# Altium schematic sheet Battery Charger.SchDoc(U_BATTERY_CHARGER)
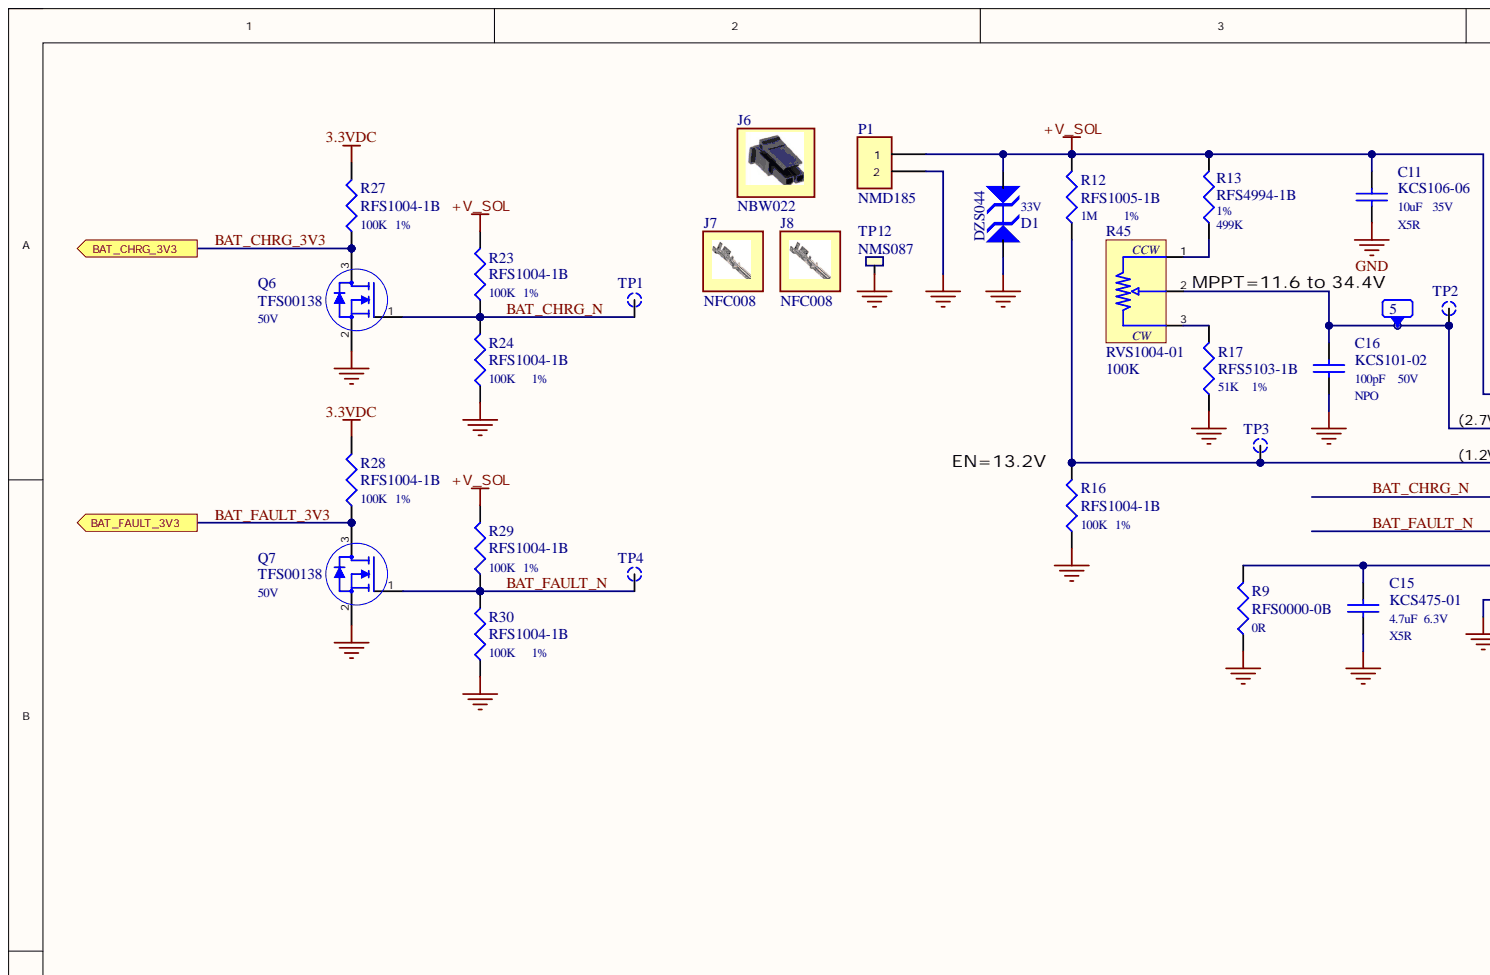
[diagram p0: Battery Charger.SchDoc, top left]
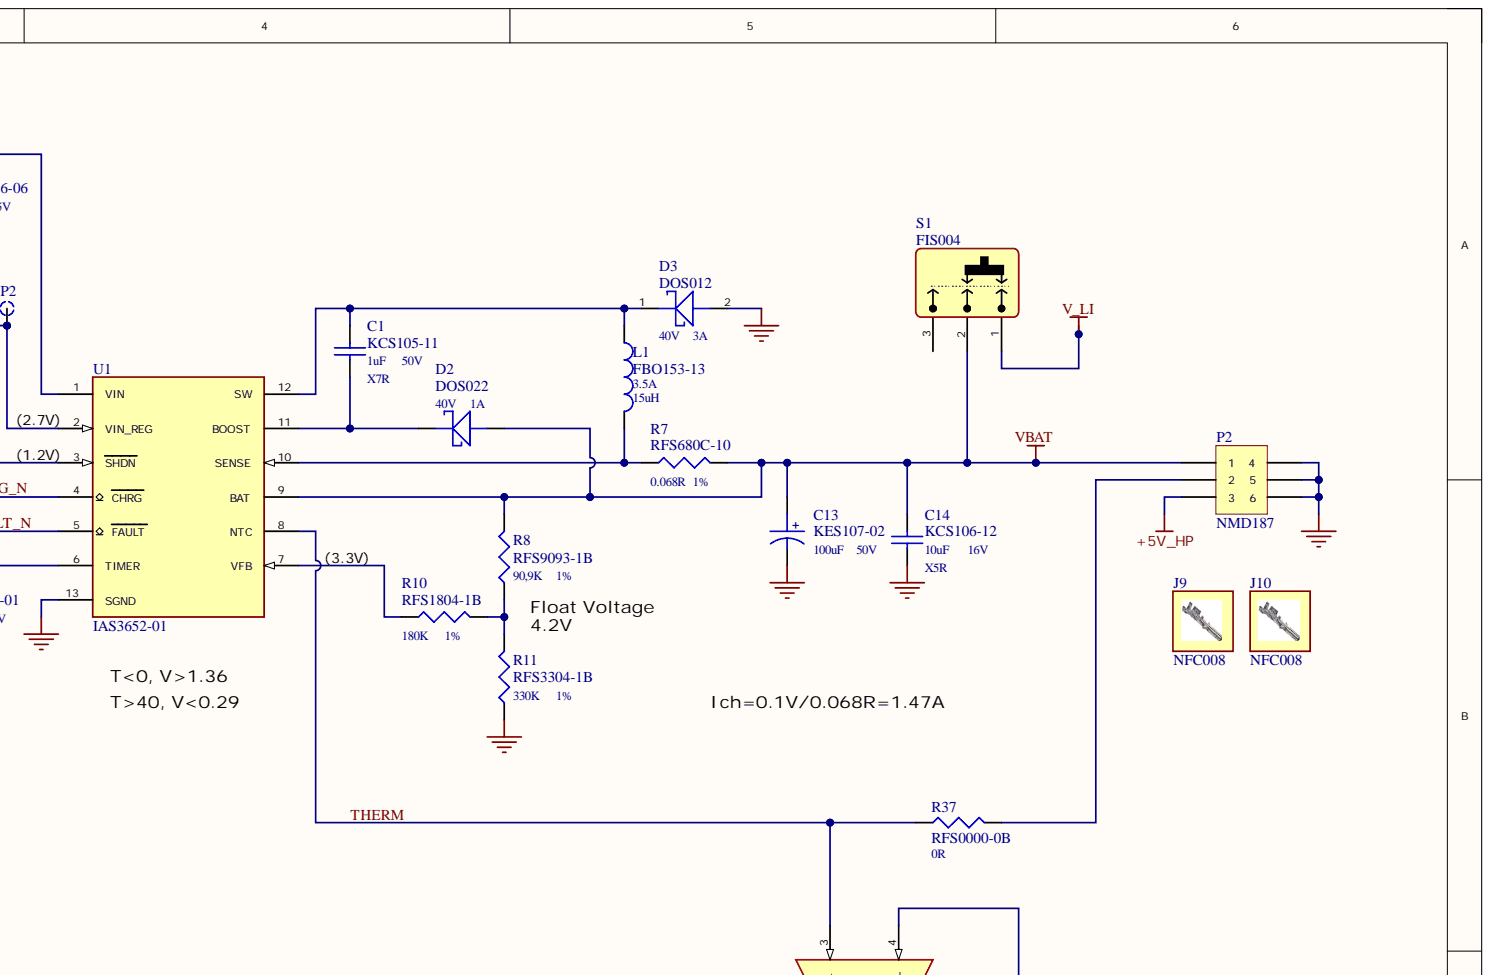
[diagram p1: Battery Charger.SchDoc, top right]
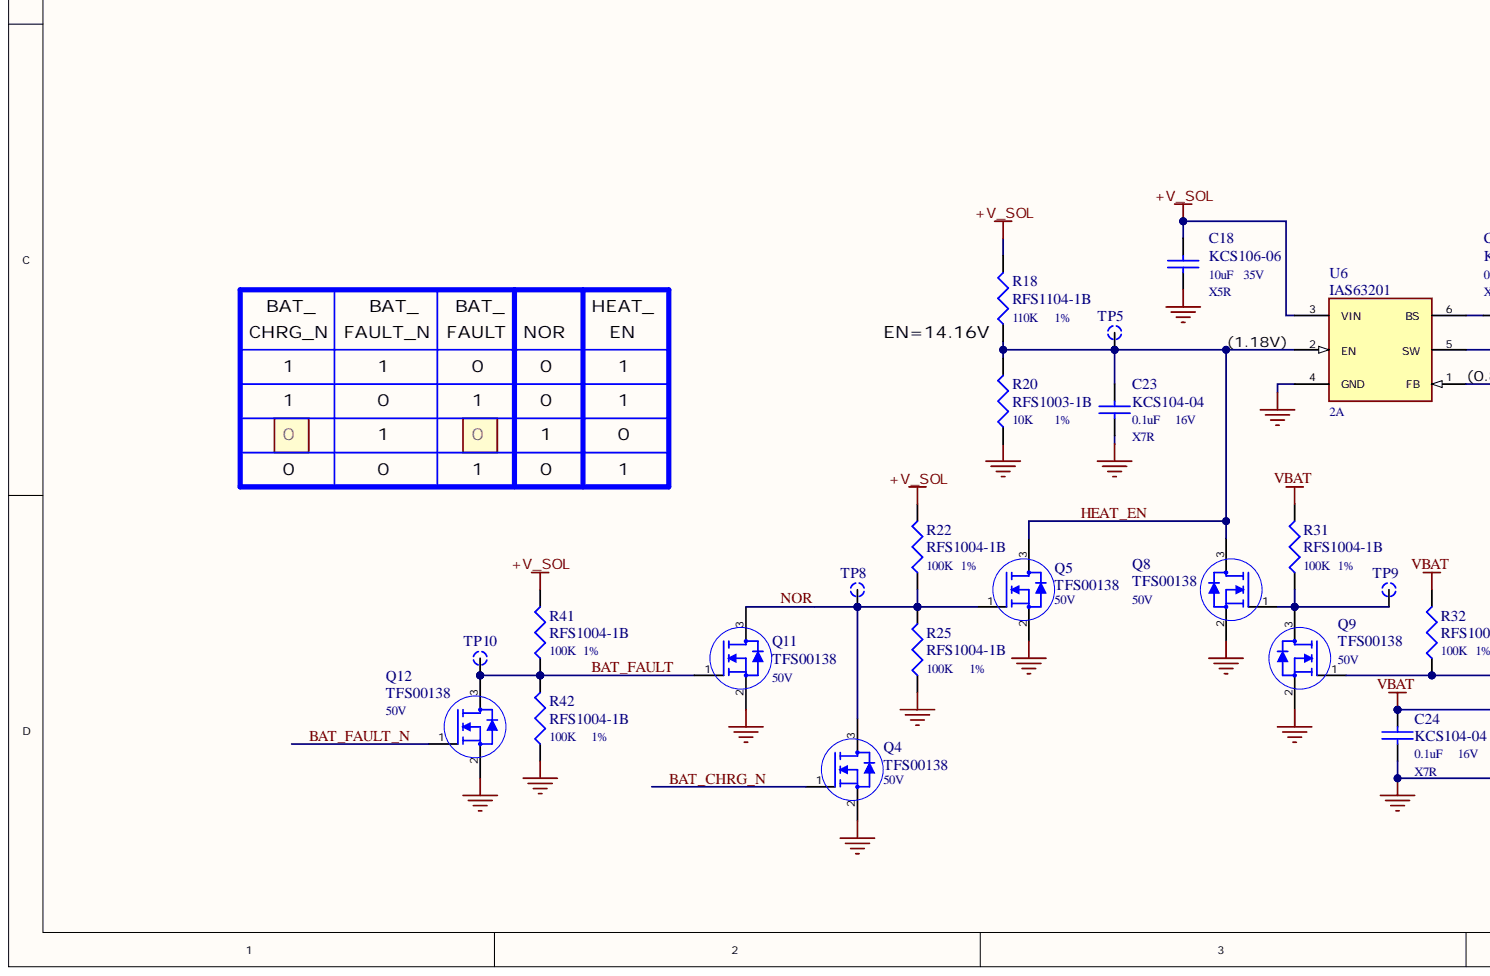
[diagram p2: Battery Charger.SchDoc, bottom left]
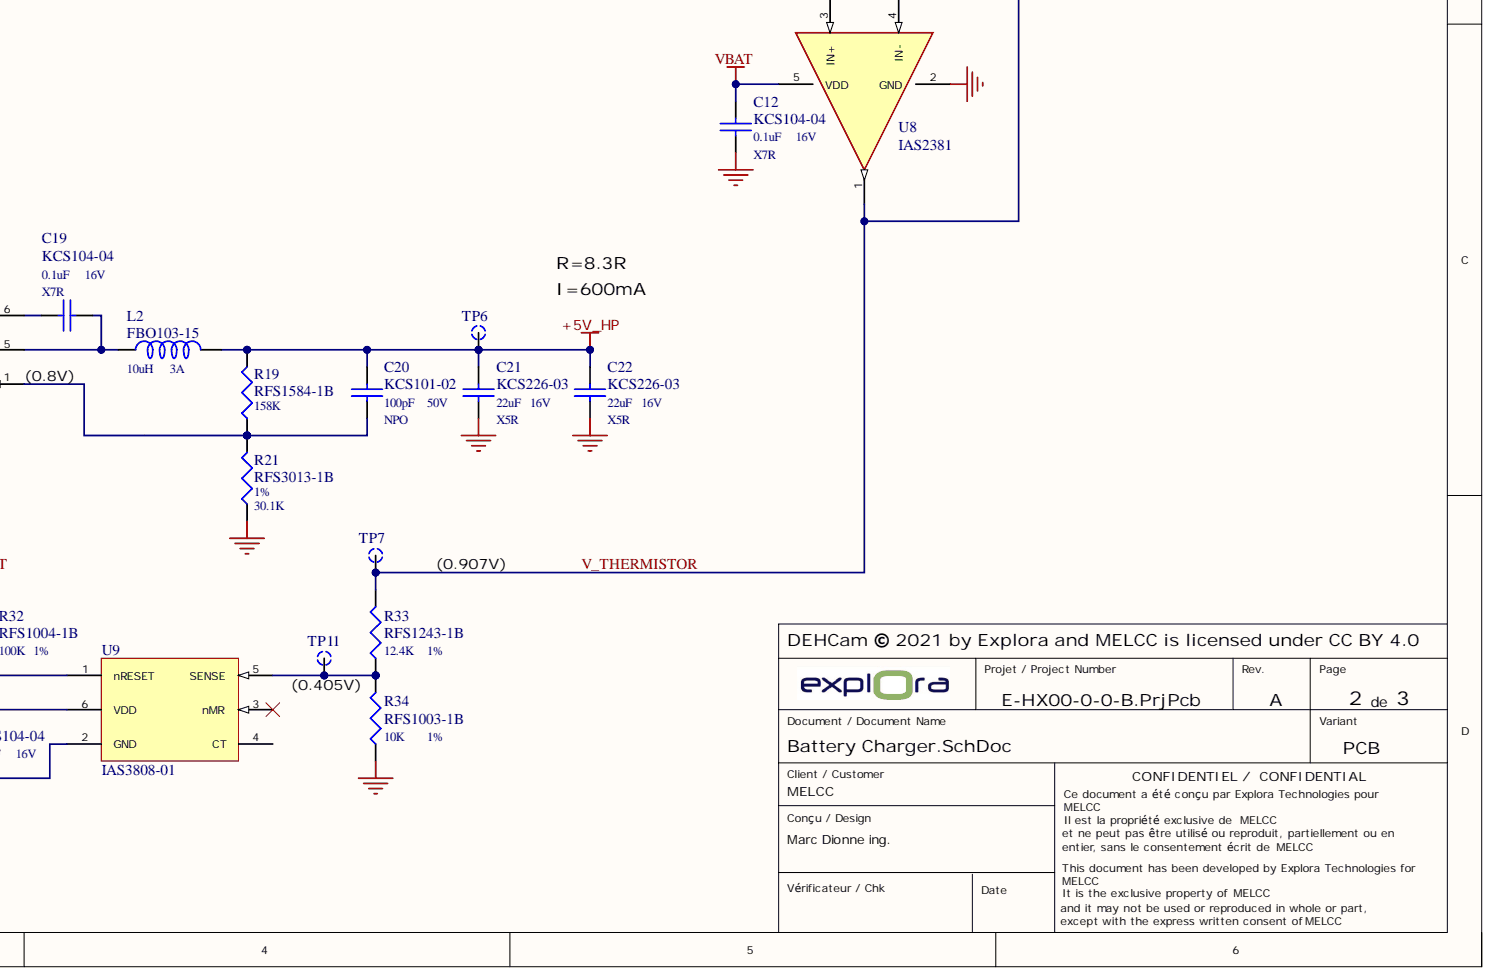
[diagram p3: Battery Charger.SchDoc, bottom right]

## Parts
5  TESTPOINT_SMALL  [TESTP_THRU - SMALL]  (value not drawn)  (no BOM)
C1  KCS105-11  1_VAL: 1uF  7_TYPE: X7R  [0603]
C11  KCS106-06  1_VAL: 10uF  7_TYPE: X5R  [1206]
C12  KCS104-04  1_VAL: 0.1uF  7_TYPE: X7R  [0402]
C13  KES107-02  1_VAL: 100uF  [KES107-02]
C14  KCS106-12  1_VAL: 10uF  7_TYPE: X5R  [0805]
C15  KCS475-01  1_VAL: 4.7uF  3_V: 6.3V  7_TYPE: X5R  [0603]
C16  KCS101-02  1_VAL: 100pF  7_TYPE: NPO  [0402]
C18  KCS106-06  1_VAL: 10uF  3_V: 35V  7_TYPE: X5R  [1206]
C19  KCS104-04  1_VAL: 0.1uF  3_V: 16V  7_TYPE: X7R  [0402]
C20  KCS101-02  1_VAL: 100pF  7_TYPE: NPO  [0402]
C21  KCS226-03  1_VAL: 22uF  7_TYPE: X5R  [1206]
C22  KCS226-03  1_VAL: 22uF  3_V: 16V  7_TYPE: X5R  [1206]
C23  KCS104-04  1_VAL: 0.1uF  7_TYPE: X7R  [0402]
C24  KCS104-04  1_VAL: 0.1uF  7_TYPE: X7R  [0402]
D1  DZS044  3_V: 33V  [SMC]
D2  DOS022  3_V: 40V  4_I: 1A  [SOD-123POL]
D3  DOS012  3_V: 40V  4_I: 3A  [SMA]
J6  NBW022
J7  NFC008
J8  NFC008
J9  NFC008
J10  NFC008
L1  FBO153-13  1_VAL: 15uH  4_I: 3.5A  [FBO153-13]
L2  FBO103-15  1_VAL: 10uH  4_I: 3A  [744065XXX]
P1  NMD185  [NMD185 - MATING 43645]
P2  NMD187  [NMD187 - MATING 43025]
Q4  TFS00138  3_V: 50V  [SOT23]
Q5  TFS00138  3_V: 50V  [SOT23]
Q6  TFS00138  [SOT23]
Q7  TFS00138  [SOT23]
Q8  TFS00138  [SOT23]
Q9  TFS00138  3_V: 50V  [SOT23]
Q11  TFS00138  3_V: 50V  [SOT23]
Q12  TFS00138  3_V: 50V  [SOT23]
R7  RFS680C-10  1_VAL: 0.068R  2_%: 1%  [0805]
R8  RFS9093-1B  1_VAL: 90,9K  2_%: 1%  [0402]
R9  RFS0000-0B  1_VAL: 0R  [0402]
R10  RFS1804-1B  1_VAL: 180K  2_%: 1%  [0402]
R11  RFS3304-1B  1_VAL: 330K  2_%: 1%  [0402]
R12  RFS1005-1B  1_VAL: 1M  [0402]
R13  RFS4994-1B  1_VAL: 499K  2_%: 1%  [0402]
R16  RFS1004-1B  1_VAL: 100K  2_%: 1%  [0402]
R17  RFS5103-1B  1_VAL: 51K  [0402]
R18  RFS1104-1B  1_VAL: 110K  [0402]
R19  RFS1584-1B  1_VAL: 158K  [0402]
R20  RFS1003-1B  1_VAL: 10K  [0402]
R21  RFS3013-1B  1_VAL: 30.1K  2_%: 1%  [0402]
R22  RFS1004-1B  1_VAL: 100K  [0402]
R23  RFS1004-1B  1_VAL: 100K  2_%: 1%  [0402]
R24  RFS1004-1B  1_VAL: 100K  2_%: 1%  [0402]
R25  RFS1004-1B  1_VAL: 100K  [0402]
R27  RFS1004-1B  1_VAL: 100K  [0402]
R28  RFS1004-1B  1_VAL: 100K  [0402]
R29  RFS1004-1B  1_VAL: 100K  2_%: 1%  [0402]
R30  RFS1004-1B  1_VAL: 100K  2_%: 1%  [0402]
R31  RFS1004-1B  1_VAL: 100K  [0402]
R32  RFS1004-1B  1_VAL: 100K  [0402]
R33  RFS1243-1B  1_VAL: 12.4K  [0402]
R34  RFS1003-1B  1_VAL: 10K  [0402]
R37  RFS0000-0B  1_VAL: 0R  [0402]
R41  RFS1004-1B  1_VAL: 100K  2_%: 1%  [0402]
R42  RFS1004-1B  1_VAL: 100K  2_%: 1%  [0402]
R45  RVS1004-01  1_VAL: 100K  [RVS_SM-31W]
S1  FIS004  [FIS004]
TP1  TESTPOINT_50  [TP50]  (value not drawn)  (no BOM)
TP2  TESTPOINT_50  [TP50]  (value not drawn)  (no BOM)
TP3  TESTPOINT_50  [TP50]  (value not drawn)  (no BOM)
TP4  TESTPOINT_50  [TP50]  (value not drawn)  (no BOM)
TP5  TESTPOINT_50  [TP50]  (value not drawn)  (no BOM)
TP6  TESTPOINT_50  [TP50]  (value not drawn)  (no BOM)
TP7  TESTPOINT_50  [TP50]  (value not drawn)  (no BOM)
TP8  TESTPOINT_50  [TP50]  (value not drawn)  (no BOM)
TP9  TESTPOINT_50  [TP50]  (value not drawn)  (no BOM)
TP10  TESTPOINT_50  [TP50]  (value not drawn)  (no BOM)
TP11  TESTPOINT_50  [TP50]  (value not drawn)  (no BOM)
TP12  NMS087  [NMS087]
U1  IAS3652-01  [MSOP12]
U6  IAS63201  4_I: 2A  [SOT23-6]
U8  IAS2381  [SOT23-5]
U9  IAS3808-01  [SOT23-6]

## Ports
BAT_CHRG_3V3, BAT_FAULT_3V3

## Nets
3.3VDC: R27.2 R28.2
+5V_HP: C20.1 C21.1 C22.1 L2.2 P2.3 R19.2 TP6.1
+V_SOL: C11.1 C18.1 D1.2 P1.1 R12.2 R13.2 R18.2 R22.2 R23.2 R29.2 R41.2 U1.1(VIN) U6.3(VIN)
GND: C11.2 C12.2 C13.2 C14.2 C15.2 C16.1 C18.2 C21.2 C22.2 C23.2 C24.2 D1.1 D3.2 P1.2 P2.4 P2.5 P2.6 Q4.2 Q5.2 Q6.2 Q7.2 Q8.2 Q9.2 Q11.2 Q12.2 R9.1 R11.1 R16.1 R17.1 R20.1 R21.1 R24.1 R25.1 R30.1 R34.1 R42.1 TP12.1 U1.13(SGND) U6.4(GND) U8.2(GND) U9.2(GND)
V_LI: S1.1
VBAT: C12.1 C13.1 C14.1 C24.1 D2.2 P2.1 R7.2 R8.2 R31.2 R32.2 S1.2 U1.9(BAT) U8.5(VDD) U9.6(VDD)
BAT_CHRG_3V3: Q6.3 R27.1 ; port BAT_CHRG_3V3
BAT_CHRG_N: Q4.1 Q6.1 R23.1 R24.2 TP1.1 U1.4(CHRG)
BAT_FAULT: Q11.1 Q12.3 R41.1 R42.2 TP10.1
BAT_FAULT_3V3: Q7.3 R28.1 ; port BAT_FAULT_3V3
BAT_FAULT_N: Q7.1 Q12.1 R29.1 R30.2 TP4.1 U1.5(FAULT)
HEAT_EN: C23.1 Q5.3 Q8.3 R18.1 R20.2 TP5.1 U6.2(EN)
NOR: Q4.3 Q5.1 Q11.3 R22.1 R25.2 TP8.1
THERM: R37.1 U1.8(NTC) U8.3(IN+)
V_THERMISTOR: R33.2 TP7.1 U8.1 U8.4(IN-)
Net5_1: 5.1 C16.2 R45.2 TP2.1 U1.2(VIN_REG)
NetC1_1: C1.1 D3.1 L1.1 U1.12(SW)
NetC1_2: C1.2 D2.1 U1.11(BOOST)
NetC15_1: C15.1 R9.2 U1.6(TIMER)
NetC19_1: C19.1 U6.6(BS)
NetC19_2: C19.2 L2.1 U6.5(SW)
NetC20_2: C20.2 R19.1 R21.2 U6.1(FB)
NetL1_2: L1.2 R7.1 U1.10(SENSE)
NetP2_2: P2.2 R37.2
NetQ8_1: Q8.1 Q9.3 R31.1 TP9.1
NetQ9_1: Q9.1 R32.1 U9.1(nRESET)
NetR8_1: R8.1 R10.1 R11.2
NetR10_2: R10.2 U1.7(VFB)
NetR12_1: R12.1 R16.2 TP3.1 U1.3(SHDN)
NetR13_1: R13.1 R45.1
NetR17_2: R17.2 R45.3
NetR33_1: R33.1 R34.2 TP11.1 U9.5(SENSE)

Not connected: S1 3; U9 3(nMR) 4(CT)

## Library data of the parts
5 = TESTPOINT_SMALL: TESTPOINT, THRU-HOLE SMALL; Library Name: MAIN.SchLib
C1 = KCS105-11: Condensateur céramique; 2_%: 10%; 3_V: 50V; Component Kind: Standard; Library Name: CAPACITORS.SchLib
C11 = KCS106-06: Condensateur céramique; 2_%: 10%; 3_V: 35V; Component Kind: Standard; Library Name: EXP_SCH_DXP.SCHLIB
C12 C23 C24 = KCS104-04: Condensateur céramique; 2_%: 10%; 3_V: 16V; Component Kind: Standard; Library Name: CAPACITORS.SchLib
C13 = KES107-02: CONDENSATEUR ELECTROLYTIQUE SMT; 2_%: 20%; 3_V: 50V; 7_TYPE: Électrolytique; Component Kind: Standard; Library Name: EXP_SCH_DXP.SCHLIB
C14 = KCS106-12: Condensateur céramique; 2_%: 10%; 3_V: 16V; Component Kind: Standard; Library Name: CAPACITORS.SchLib
C15 = KCS475-01: Condensateur céramique; 2_%: 10%; 8_EXTRA1: 1-CO00046; Component Kind: Standard; Library Name: EXP_SCH_DXP.SCHLIB
C16 C20 = KCS101-02: Condensateur céramique; 2_%: 5%; 3_V: 50V; Component Kind: Standard
  C20: Library Name: CAPACITORS.SchLib
  C16: Library Name: EXP_SCH_DXP.SCHLIB
C18 = KCS106-06: Condensateur céramique; 2_%: 10%; Component Kind: Standard; Library Name: EXP_SCH_DXP.SCHLIB
C19 = KCS104-04: Condensateur céramique; 2_%: 10%; Component Kind: Standard; Library Name: CAPACITORS.SchLib
C21 = KCS226-03: Condensateur céramique; 2_%: +/- 20%; 3_V: 16V; Component Kind: Standard; Library Name: CAPACITORS.SchLib
C22 = KCS226-03: Condensateur céramique; 2_%: +/- 20%; Component Kind: Standard; Library Name: CAPACITORS.SchLib
D1 = DZS044: DIODE TVS 33V 3000W 5% BI SMD; 5_W: 3000W; Component Kind: Standard; Library Name: EXP_SCH_DXP.SCHLIB
D2 = DOS022: Diode Schotky  1A 40V; Component Kind: Standard; Library Name: EXP_SCH_DXP.SCHLIB
D3 = DOS012: Diode Schottky 3A 40V SMA; Component Kind: Standard; Library Name: EXP_SCH_DXP.SCHLIB
J6 = NBW022: CONN RECEPT 2POS 3MM SINGLE ROW; Component Kind: Standard; Library Name: CONNECTORS.SchLib
J7-J10 = NFC008: CONN SOCKET 20-24AWG CRIMP TIN; Component Kind: Standard; Library Name: CONNECTORS.SchLib
L1 = FBO153-13: BOBINE 15µH Shielded Wirewound Inductor 3.5A 52.8mOhm Max Nonstandard; 2_%: 20%; Component Kind: Standard; Library Name: INDUCTORS.SchLib
L2 = FBO103-15: INDUCTOR POWER 10UH 3.0A; 2_%: 30%; Component Kind: Standard; Library Name: INDUCTORS.SchLib
P1 = NMD185: CONN HEADER 2POS 3MM VERT TIN MICROFIT 3.0; Component Kind: Standard; Library Name: CONNECTORS.SchLib
P2 = NMD187: 6 POS (3x2) Header, Shrouded Connector (3.00mm) Tin Through Hole; Component Kind: Standard; Library Name: CONNECTORS.SchLib
Q4 Q5 Q9 Q11 Q12 = TFS00138: N-CH ENHANCEMENT MODE FET; Component Kind: Standard; Library Name: TRANSISTORS.SchLib; Simulation: bss138lt1
Q6-Q8 = TFS00138: N-CH ENHANCEMENT MODE FET; 3_V: 50V; Component Kind: Standard; Library Name: TRANSISTORS.SchLib; Simulation: bss138lt1
R7 = RFS680C-10: Résistance fixe SMT; 5_W: 0.5W; Component Kind: Standard; Library Name: RESISTORS.SchLib
R8 = RFS9093-1B: Resistance Fixe Smt; 5_W: 0.0625W; Component Kind: Standard; Library Name: RESISTORS.SchLib
R9 R37 = RFS0000-0B: Résistance fixe SMT; 2_%: 5%; 5_W: 1/16W; Component Kind: Standard
  R37: Library Name: RESISTORS.SchLib
  R9: Library Name: EXP_SCH_DXP.SCHLIB
R10 = RFS1804-1B: Resistance Fixe smt; 5_W: 1/10W; Component Kind: Standard; Library Name: RESISTORS.SchLib
R11 = RFS3304-1B: Resistance Fixe Smt; 5_W: 1/16W; Component Kind: Standard; Library Name: EXP_SCH_DXP.SCHLIB
R12 = RFS1005-1B: Resistance Fixe Smt; 2_%: 1%; 5_W: 1/16W; Component Kind: Standard; Library Name: EXP_SCH_DXP.SCHLIB
R13 = RFS4994-1B: Resistance Fixe Smt; 5_W: 0.0625W; Component Kind: Standard; Library Name: EXP_SCH_DXP.SCHLIB
R16 R23 R24 R29 R30 R41 R42 = RFS1004-1B: Resistance Fixe Smt; 5_W: 0.0625W; Component Kind: Standard
  R23 R24 R29 R30 R41 R42: Library Name: RESISTORS.SchLib
  R16: Library Name: EXP_SCH_DXP.SCHLIB
R17 = RFS5103-1B: Resistance Fixe Smt; 2_%: 1%; 5_W: 1/16W; Component Kind: Standard; Library Name: RESISTORS.SchLib
R18 = RFS1104-1B: Resistance Fixe Smt; 2_%: 1%; 5_W: 0.0625W; Component Kind: Standard; Library Name: RESISTORS.SchLib
R19 = RFS1584-1B: Resistance Fixe Smt; 2_%: 1%; Component Kind: Standard; Library Name: RESISTORS.SchLib
R20 R34 = RFS1003-1B: Resistance Fixe Smt; 2_%: 1%; 5_W: 0.0625W; Component Kind: Standard; Library Name: RESISTORS.SchLib
R21 = RFS3013-1B: Resistance Fixe Smt; 5_W: 1/16W; Component Kind: Standard; Library Name: RESISTORS.SchLib
R22 R25 R27 R28 R31 R32 = RFS1004-1B: Resistance Fixe Smt; 2_%: 1%; 5_W: 0.0625W; Component Kind: Standard
  R22 R25 R31 R32: Library Name: RESISTORS.SchLib
  R27 R28: Library Name: EXP_SCH_DXP.SCHLIB
R33 = RFS1243-1B: Resistance Fixe Smt; 2_%: 1%; 5_W: 0.0625 W; Component Kind: Standard; Library Name: RESISTORS.SchLib
R45 = RVS1004-01: Resistance variable 100K SMD TOP ADJ; 5_W: 0.125W; Component Kind: Standard; Library Name: RESISTORS.SchLib
S1 = FIS004: SWITCH SLIDE SPDT 3A 125V; Component Kind: Standard; Library Name: MAIN.SchLib
TP1-TP11 = TESTPOINT_50: Test Point SMT; Library Name: MAIN.SchLib
TP12 = NMS087: SURFACE MOUNT TESTPOINT; Component Kind: Standard; Library Name: CONNECTORS.SchLib
U1 = IAS3652-01: BATTERY CHARGER 2A MPPT; Component Kind: Standard; Library Name: IC.SchLib
U6 = IAS63201: Buck Switching Regulator IC Positive Adjustable 1.2V 1 Output 2A SOT-23-6 Thin, TSOT-23-6; Component Kind: Standard; Library Name: IC.SchLib
U8 = IAS2381: OP AMP RAIlL TO RAIL I/O; Component Kind: Standard; Library Name: IC.SchLib
U9 = IAS3808-01: VOLTAGE SUPERVISOR ADJ; Component Kind: Standard; Library Name: IC.SchLib
